# Altium schematic sheet CANTransceiver.SchDoc(CANTransceiver)
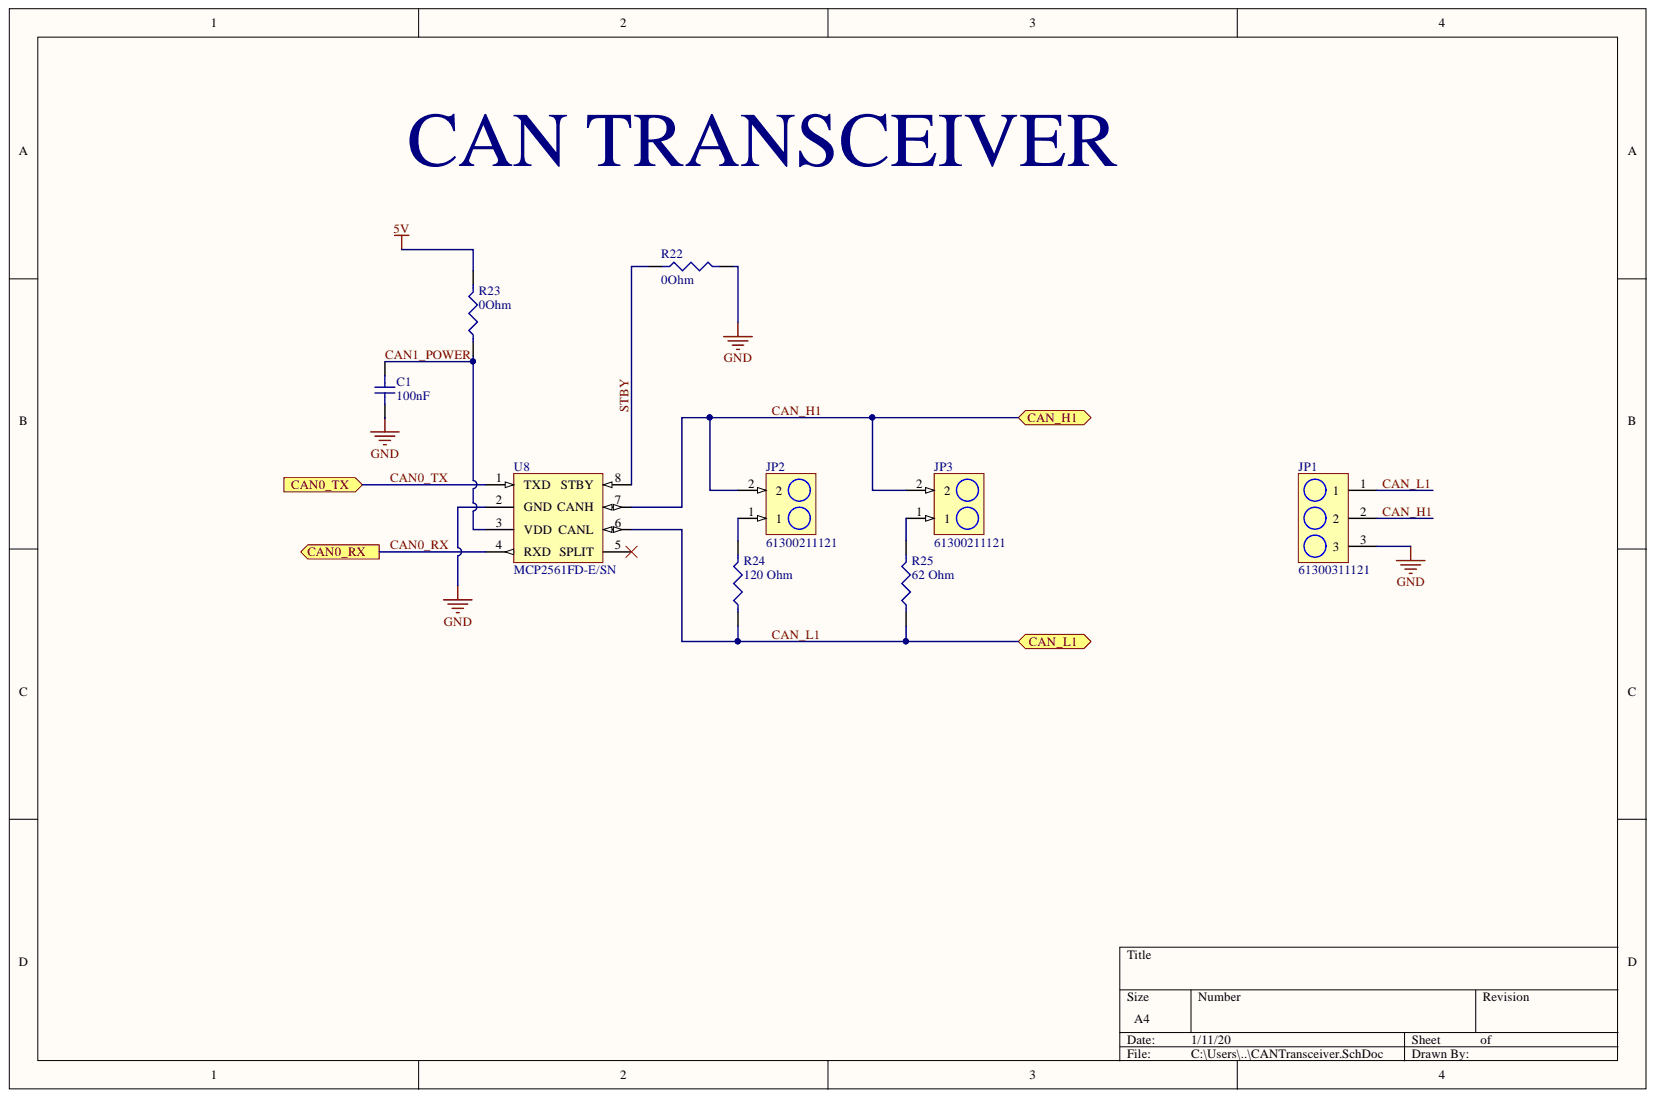
[diagram p0: CANTransceiver.SchDoc]

## Parts
C1  100nF  [CAPC1608X10N]
JP1  61300311121  [61300311121]
JP2  61300211121  [61300211121]
JP3  61300211121  [61300211121]
R22  0Ohm  [RES-0603]
R23  0Ohm  [RES-0603]
R24  120 Ohm  [RESC1608X06N]
R25  62 Ohm  [60Ohm-0603]
U8  MCP2561FD-E/SN  [SOIC127P600X175-8N]

## Ports
CAN0_RX, CAN0_TX, CAN_H1, CAN_L1

## Nets
5V: R23.2
GND: C1.1 JP1.3 R22.2 U8.2(GND)
CAN0_RX: U8.4(RXD) ; port CAN0_RX
CAN0_TX: U8.1(TXD) ; port CAN0_TX
CAN1_POWER: C1.2 R23.1 U8.3(VDD)
CAN_H1: JP1.2 JP2.2 JP3.2 U8.7(CANH) ; port CAN_H1
CAN_L1: JP1.1 R24.1 R25.1 U8.6(CANL) ; port CAN_L1
STBY: R22.1 U8.8(STBY)
NetJP2_1: JP2.1 R24.2
NetJP3_1: JP3.1 R25.2

Not connected: U8 5(SPLIT)

## Library data of the parts
C1 = C0603C104K4RAC7411: Cap Ceramic 0.1uF 16V X7R 10% SMD 0603 125C Paper T/R; Manufacturer: KEMET; Dissipation Factor: 3.5%; Width: 0.8mm; Voltage Rating (DC): 16V; Voltage Rating: 16V; Tolerance: 10%; Termination: SMD/SMT; Supplier Part Number 1: 399-17393-1-ND; Supplier 1: Digi-Key; Stock 1: 26049; RoHS: Yes; Radiation Hardening: No; Pricing 1: 1=0.14000, 10=0.09800, 100=0.04620, 500=0.03240, 1000=0.02683, 2500=0.02497, 5000=0.02285 (USD); Packaging: Tape and Reel; Package Quantity: 10000; Mount: Surface Mount; Min Operating Temperature: -55°C; Max Operating Temperature: 125°C; Manufacturer Part Number: C0603C104K4RAC7411; Length: 1.6mm; Lead Pitch: 0.7mm; Height: 0.8mm; Dielectric Material: Ceramic; Dielectric: X7R; Depth: 0.8mm; ComponentLink1URL: https://datasheet.ciiva.com/5411/kem-c1002-x7r-smd-357932-5411657.pdf?src-supplier=Mouser; ComponentLink1Description: https://datasheet.ciiva.com/5411/kem-c1002-x7r-smd-357932-5411657.pdf?src-supplier=Mouser; Component Kind: Standard; Case Code (Metric): 1608; Case Code (Imperial): 0603; Case/Package: 0603; Capacitance: 100nF; Library Name: Dashboard.SchLib
JP1 = 61300311121: WURTH ELEKTRONIK - 61300311121 - HEADER, 2.54MM, PIN, THT, VERTICAL, 3WAY; Manufacturer: Wurth Electronics; Pitch: 0.1inch; Number of Positions: 3; Gender: Male; Connector Type: Male Pin; Termination: Solder; Supplier Part Number 1: 732-5316-ND; Supplier 1: Digi-Key; Stock 1: 56311; RoHS: Yes; REACH SVHC: Unknown; Pricing 1: 1=0.13000, 10=0.10600, 25=0.08800, 50=0.07400, 100=0.06700, 1000=0.06100 (USD); Packaging: Bulk; Number of Rows: 1; Number of Contacts: 3; Mount: Through Hole; Manufacturer Part Number: 61300311121; Lead Free: Lead Free; Contact Plating: Gold; Contact Mating Length: 0.236inch; Contact Material: Copper; ComponentLink2URL: https://katalog.we-online.de/em/datasheet/6130xx11121.pdf?src-supplier=Digi-Key; ComponentLink2Description: https://katalog.we-online.de/em/datasheet/6130xx11121.pdf?src-supplier=Digi-Key; ComponentLink1URL: http://www.farnell.com/cad/1737268.pdf?src-supplier=Element14; ComponentLink1Description: http://www.farnell.com/cad/1737268.pdf?src-supplier=Element14; Component Kind: Standard; Color: Black; Library Name: Dashboard.SchLib
JP2 JP3 = 61300211121: Headers & Wire Housings WR-PHD 2.54mm 2Pin THT Header; Manufacturer: Wurth Electronics; Voltage Rating: 250V; Pitch: 0.1inch; Number of Positions: 2; Gender: Male; Current Rating: 3A; Connector Type: Male Pin; Termination: Through Hole; Supplier Part Number 1: 732-5315-ND; Supplier 1: Digi-Key; Stock 1: 191551; RoHS: Yes; REACH SVHC: Unknown; Pricing 1: 1=0.13000, 10=0.10600, 25=0.08800, 50=0.07400, 100=0.06700, 1000=0.06100 (USD); Packaging: Bulk; Number of Rows: 1; Number of Contacts: 2; Mount: Through Hole; Min Operating Temperature: -40°C; Max Operating Temperature: 125°C; Manufacturer Part Number: 61300211121; Lead Free: Lead Free; Housing Material: PBT; Contact Plating: Gold; Contact Mating Length: 0.236inch; Contact Material: Copper Alloy,Copper; Contact Gender: Male; ComponentLink1URL: https://datasheet.ciiva.com/13619/6130xx11121-48870-13619345.pdf?src-supplier=Mouser; ComponentLink1Description: https://datasheet.ciiva.com/13619/6130xx11121-48870-13619345.pdf?src-supplier=Mouser; Component Kind: Standard; Color: Black; Library Name: Dashboard.SchLib
R22 R23 = RC0603JR-070RL: RES SMD 0 OHM JUMPER 1/10W 0603; Manufacturer: Yageo; Voltage Rating (DC): 50V; Tolerance: 1%; Temperature Coefficient: 200ppm/°C; Resistance: 0mR; Power Rating: 100mW; Width: 0.8mm; Voltage Rating: 50V; Termination: SMD/SMT; Supplier Part Number 1: 311-0.0GRCT-ND; Supplier 1: Digi-Key; Stock 1: 36135548; RoHS: Yes; REACH SVHC: No SVHC; Radiation Hardening: No; Pricing 1: 1=0.10000, 10=0.01900, 25=0.01360, 50=0.01040, 100=0.00770, 250=0.00588, 500=0.00472, 1000=0.00347 (USD); Packaging: Tape and Reel; Package Quantity: 5000; Number of Pins: 2; Min Operating Temperature: -55°C; Military Standard: Not; Max Operating Temperature: 155°C; Manufacturer Part Number: RC0603JR-070RL; Length: 1.6mm; Lead Free: Lead Free; Height: 0.45mm; Depth: 0.8mm; Contact Plating: Tin,Matte; Composition: Thick Film; ComponentLink7URL: https://datasheet.ciiva.com/22747/443971-22747530.pdf?src-supplier=Element14; ComponentLink7Description: https://datasheet.ciiva.com/22747/443971-22747530.pdf?src-supplier=Element14; ComponentLink6URL: https://datasheet.ciiva.com/12555/rc0603-272842-12555254.pdf?src-supplier=Mouser; ComponentLink6Description: https://datasheet.ciiva.com/12555/rc0603-272842-12555254.pdf?src-supplier=Mouser; ComponentLink5URL: https://datasheet.ciiva.com/7746/1738695-7746422.pdf?src-supplier=Element14; ComponentLink5Description: https://datasheet.ciiva.com/7746/1738695-7746422.pdf?src-supplier=Element14; ComponentLink4URL: https://datasheet.ciiva.com/15189/getdatasheetpartid-329462-15189845.pdf?src-supplier=Verical; ComponentLink4Description: https://datasheet.ciiva.com/15189/getdatasheetpartid-329462-15189845.pdf?src-supplier=Verical; ComponentLink3URL: https://datasheet.ciiva.com/8883/pyu-rc0603-51-rohs-l-4-8883045.pdf?src-supplier=Avnet; ComponentLink3Description: https://datasheet.ciiva.com/8883/pyu-rc0603-51-rohs-l-4-8883045.pdf?src-supplier=Avnet; ComponentLink2URL: https://datasheet.ciiva.com/7746/1738695-7746421.pdf?src-supplier=Element14; ComponentLink2Description: https://datasheet.ciiva.com/7746/1738695-7746421.pdf?src-supplier=Element14; ComponentLink1URL: https://datasheet.ciiva.com/11808/pyu-r-int-6-11808700.pdf?src-supplier=RSComponents; ComponentLink1Description: https://datasheet.ciiva.com/11808/pyu-r-int-6-11808700.pdf?src-supplier=RSComponents; Component Kind: Standard; Case Code (Metric): 1608; Case Code (Imperial): 0603; Case/Package: 0603; Library Name: Dashboard.SchLib
R24 = ERA-3AEB121V: Metal film resistor 120 Ω SMD 0603 0.1 W; Manufacturer: Panasonic; Voltage Rating (DC): 75V; Tolerance: 0.1%; Temperature Coefficient: 25ppm/°C; Resistance: 120R; Power Rating: 100mW; Width: 0.8mm; Voltage Rating: 75V; Termination: SMD/SMT; Supplier Part Number 1: P120DBCT-ND; Supplier 1: Digi-Key; Stock 1: 121177; RoHS: Yes; REACH SVHC: No SVHC; Radiation Hardening: No; Pricing 1: 1=0.35000, 10=0.30000, 100=0.11730, 1000=0.04908, 2500=0.04499 (USD); Packaging: Tape and Reel; Package Quantity: 1; Number of Terminations: 2; Number of Pins: 2; Mount: Surface Mount; Min Operating Temperature: -55°C; Military Standard: Not; Max Power Dissipation: 100mW; Max Operating Temperature: 155°C; Manufacturer Part Number: ERA-3AEB121V; Length: 1.6mm; Lead Free: Lead Free; Height: 0.45mm; Features: Automotive AEC-Q200; Depth: 0.8mm; Contact Plating: Tin; Composition: Thin Film; ComponentLink11URL: https://datasheet.ciiva.com/9186/aoa0000ce26-9186155.pdf?src-supplier=Avnet; ComponentLink11Description: https://datasheet.ciiva.com/9186/aoa0000ce26-9186155.pdf?src-supplier=Avnet; ComponentLink10URL: https://datasheet.ciiva.com/9117/aoa0000ce26-9117338.pdf?src-supplier=Avnet; ComponentLink10Description: https://datasheet.ciiva.com/9117/aoa0000ce26-9117338.pdf?src-supplier=Avnet; ComponentLink9URL: https://datasheet.ciiva.com/5999/485442-5999050.pdf?src-supplier=Farnell; ComponentLink9Description: https://datasheet.ciiva.com/5999/485442-5999050.pdf?src-supplier=Farnell; ComponentLink8URL: https://datasheet.ciiva.com/48534/era-eng-tds-48534505.pdf?src-supplier=Distrelec; ComponentLink8Description: https://datasheet.ciiva.com/48534/era-eng-tds-48534505.pdf?src-supplier=Distrelec; ComponentLink7URL: https://datasheet.ciiva.com/43457/485442-43457753.pdf?src-supplier=Farnell; ComponentLink7Description: https://datasheet.ciiva.com/43457/485442-43457753.pdf?src-supplier=Farnell; ComponentLink6URL: https://datasheet.ciiva.com/43455/485442-43455209.pdf?src-supplier=Farnell; ComponentLink6Description: https://datasheet.ciiva.com/43455/485442-43455209.pdf?src-supplier=Farnell; ComponentLink5URL: https://datasheet.ciiva.com/5999/485442-5999048.pdf?src-supplier=Farnell; ComponentLink5Description: https://datasheet.ciiva.com/5999/485442-5999048.pdf?src-supplier=Farnell; ComponentLink4URL: https://datasheet.ciiva.com/48425/era-eng-tds-48425415.pdf?src-supplier=Distrelec; ComponentLink4Description: https://datasheet.ciiva.com/48425/era-eng-tds-48425415.pdf?src-supplier=Distrelec; ComponentLink3URL: https://datasheet.ciiva.com/30214/54aoa0000ce26-30214359.pdf?src-supplier=Onlinecomponents.com; ComponentLink3Description: https://datasheet.ciiva.com/30214/54aoa0000ce26-30214359.pdf?src-supplier=Onlinecomponents.com; ComponentLink2URL: https://datasheet.ciiva.com/22737/1776116-22737254.pdf?src-supplier=Element14; ComponentLink2Description: https://datasheet.ciiva.com/22737/1776116-22737254.pdf?src-supplier=Element14; ComponentLink1URL: https://datasheet.ciiva.com/11808/0900766b80fe20f7-11808026.pdf?src-supplier=RSComponents; ComponentLink1Description: https://datasheet.ciiva.com/11808/0900766b80fe20f7-11808026.pdf?src-supplier=RSComponents; Component Kind: Standard; Case Code (Metric): 1608; Case Code (Imperial): 0603; Case/Package: 0603; Library Name: Dashboard.SchLib
R25 = ESR03EZPJ620: RES SMD 62 OHM 5% 1/4W 0603; Manufacturer: Rohm; Temperature Coefficient: 200ppm/°C; Resistance: 62R; Max Power Dissipation: 250mW; Width: 0.032inch; Tolerance: 5%; Supplier Part Number 1: RHM62DCT-ND; Supplier 1: Digi-Key; Stock 1: 29224; RoHS: Yes; Pricing 1: 1=0.10000, 10=0.07900, 100=0.03030, 1000=0.01331, 2500=0.01150 (USD); Packaging: Tape and Reel; Package Quantity: 1; Number of Terminations: 2; Min Operating Temperature: -55°C; Max Operating Temperature: 155°C; Manufacturer Part Number: ESR03EZPJ620; Length: 0.063inch; Height - Seated (Max): 0.022inch; Height: 0.022inch; Features: Automotive AEC-Q200; Composition: Thick Film; ComponentLink3URL: https://datasheet.ciiva.com/2516/esr-2516069.pdf?src-supplier=Digi-Key; ComponentLink3Description: https://datasheet.ciiva.com/2516/esr-2516069.pdf?src-supplier=Digi-Key; ComponentLink2URL: https://datasheet.ciiva.com/2516/esr-2516068.pdf?src-supplier=Digi-Key; ComponentLink2Description: https://datasheet.ciiva.com/2516/esr-2516068.pdf?src-supplier=Digi-Key; ComponentLink1URL: https://datasheet.ciiva.com/2516/esr-2516067.pdf?src-supplier=Digi-Key; ComponentLink1Description: https://datasheet.ciiva.com/2516/esr-2516067.pdf?src-supplier=Digi-Key; Component Kind: Standard; Case Code (Metric): 1608; Case Code (Imperial): 0603; Case/Package: 0603; Library Name: Dashboard.SchLib
U8 = MCP2561FD-E/SN: MICROCHIP         MCP2561FD-E/SN            CAN Bus, Transceiver, CAN, 1, 1, 4.5 V, 5.5 V, SOIC; Receiver Hysteresis: 200mV; Operating Supply Current: 70mA; Number of Transmit Buffers: 1; Min Supply Voltage: 4.5V; Max Supply Voltage: 5.5V; Data Rate: 8Mbps; Width: 3.9mm; Weight: 0.019048oz; Supplier Part Number 1: MCP2561FD-E/SN-ND; Supplier 1: Digi-Key; Stock 1: 1190; RoHS: Yes; REACH SVHC: No SVHC; Pricing 1: 1=0.92000, 25=0.77000 (USD); Packaging: Rail/Tube; Package Quantity: 100; Number of Transceivers: 1; Number of Receivers: 1; Number of Receive Buffers: 1; Number of Pins: 8; Number of Drivers: 1; Mount: Surface Mount; Min Operating Temperature: -40°C; Max Supply Current: 70mA; Max Operating Temperature: 125°C; Manufacturer Part Number: MCP2561FD-E/SN; Manufacturer: Microchip; Logic Function: Transceiver; Length: 4.9mm; Lead Free: Lead Free; Interface: CAN; Height: 1.5mm; ComponentLink4URL: https://datasheet.ciiva.com/48473/20005284a-48473177.pdf?src-supplier=Avnet; ComponentLink4Description: https://datasheet.ciiva.com/48473/20005284a-48473177.pdf?src-supplier=Avnet; ComponentLink3URL: https://datasheet.ciiva.com/46406/1821190-46406056.pdf?src-supplier=Element14; ComponentLink3Description: https://datasheet.ciiva.com/46406/1821190-46406056.pdf?src-supplier=Element14; ComponentLink2URL: https://datasheet.ciiva.com/46406/1821190-46406024.pdf?src-supplier=Element14; ComponentLink2Description: https://datasheet.ciiva.com/46406/1821190-46406024.pdf?src-supplier=Element14; ComponentLink1URL: https://datasheet.ciiva.com/48512/mcp2561fd-eng-tds-48512069.pdf?src-supplier=Distrelec; ComponentLink1Description: https://datasheet.ciiva.com/48512/mcp2561fd-eng-tds-48512069.pdf?src-supplier=Distrelec; Component Kind: Standard; Case/Package: SOIC; Library Name: Dashboard.SchLib
